# Altium schematic sheet MCU.SchDoc(MCU)
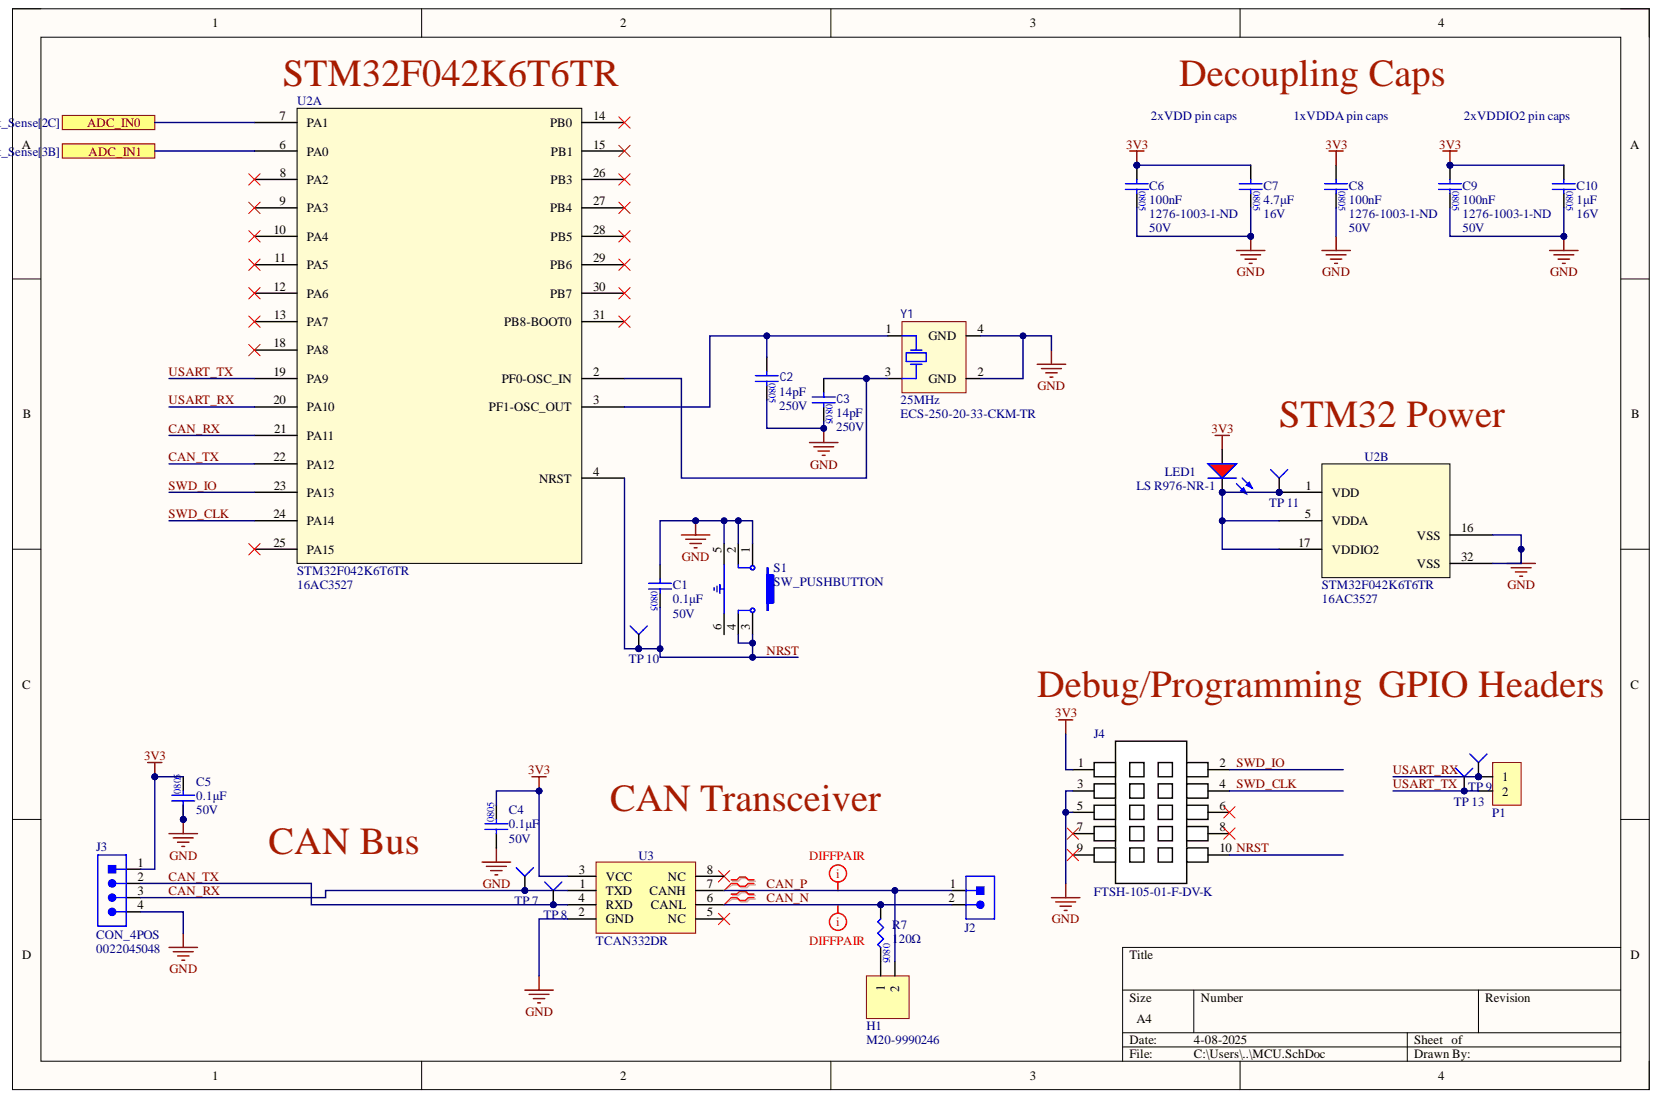
[diagram p0: MCU.SchDoc]

## Parts
C1  value 0.1μF  Package/Footprint: 0805  Voltage Rating: 50V  [CAP2012]  (hidden comment: CAP_0.1uF_50V_0805)
C2  value 14pF  Package: 0805  Voltage Rating: 250V  [CAP0805]  (hidden comment: CAP_14pF_50V_0805)
C3  value 14pF  Package: 0805  Voltage Rating: 250V  [CAP0805]  (hidden comment: CAP_14pF_50V_0805)
C4  value 0.1μF  Package/Footprint: 0805  Voltage Rating: 50V  [CAP0805]  (hidden comment: CAP_0.1uF_50V_0805)
C5  value 0.1μF  Package/Footprint: 0805  Voltage Rating: 50V  [CAP0805]  (hidden comment: CAP_0.1uF_50V_0805)
C6  value 100nF  Package/Footprint: 0805  Supplier Part Number 1: 1276-1003-1-ND  Voltage Rating: 50V  [CAP0805]  (hidden comment: CAP_100nF_50V_0805)
C7  value 4.7μF  Package: 0805  Voltage Rating: 16V  [CAP0805]  (hidden comment: CAP_4.7uF_16V_0805)
C8  value 100nF  Package/Footprint: 0805  Supplier Part Number 1: 1276-1003-1-ND  Voltage Rating: 50V  [CAP0805]  (hidden comment: CAP_100nF_50V_0805)
C9  value 100nF  Package/Footprint: 0805  Supplier Part Number 1: 1276-1003-1-ND  Voltage Rating: 50V  [CAP0805]  (hidden comment: CAP_100nF_50V_0805)
C10  value 1μF  Package: 0805  Voltage Rating: 16V  [CAP0805]  (hidden comment: CAP_1uF_16V_0805)
H1  Manufacturer Part Number 1: M20-9990246  [HDR1X2]  (hidden comment: HEADER)
J2  CON_2POS_SCREW_TERM  [1935161]  (value not drawn)
J3  CON_4POS  Manufacturer Part Number: 0022045048
J4  Manufacturer Part Number 1: FTSH-105-01-F-DV-K  [FTSH-105-01-F-DV-K-P-TR]  (hidden comment: CON_PROGRAMMING)
LED1  LED_RED  [LED_0805_RED]  (value not drawn)
P1  CONN_TERMINAL_2  [OSTYK51102030]  (value not drawn)
R7  value 120Ω  Package/Footprint: 0805  [RES0805]  (hidden comment: RES_120Ω_0805_1%)
S1  SW_PUSHBUTTON  [TACTILE_SWITCH_SMD]
TP_7  TESTPOINT_1MM_CIRCLE  [TESTPOINT_CIRCLE_1MM]  (value not drawn)  (no BOM)
TP_8  TESTPOINT_1MM_CIRCLE  [TESTPOINT_CIRCLE_1MM]  (value not drawn)  (no BOM)
TP_9  TESTPOINT_1MM_CIRCLE  [TESTPOINT_CIRCLE_1MM]  (value not drawn)  (no BOM)
TP_10  TESTPOINT_1MM_CIRCLE  [TESTPOINT_CIRCLE_1MM]  (value not drawn)  (no BOM)
TP_11  TESTPOINT_1MM_CIRCLE  [TESTPOINT_CIRCLE_1MM]  (value not drawn)  (no BOM)
TP_13  TESTPOINT_1MM_CIRCLE  [TESTPOINT_CIRCLE_1MM]  (value not drawn)  (no BOM)
U2  STM32F042K6T6TR  Supplier Part Number 1: 16AC3527  [LQFP-32]  (parts A,B)
U3  TCAN332DR  [SOIC127P599X175-8N]
Y1  Frequency: 25MHz  Manufacturer Part Number 1: ECS-250-20-33-CKM-TR  [XTAL_ECS-250-20-33-CKM-TR]  (hidden comment: CRYSTAL_25MHZ_20PF)

## Ports
ADC_IN0, ADC_IN1

## Nets
3V3: C4.2 C5.2 C6.1 C7.1 C8.1 C9.1 C10.1 J3.1 J4.1 LED1.1 U3.3(VCC)
GND: C1.1 C2.2 C3.2 C4.1 C5.1 C6.2 C7.2 C8.2 C9.2 C10.2 J3.4 J4.3 J4.5 S1.1 S1.2 S1.5 U2.16(VSS) U2.32(VSS) U3.2(GND) Y1.2(GND) Y1.4(GND)
ADC_IN0: U2.7(PA1) ; port ADC_IN0
ADC_IN1: U2.6(PA0) ; port ADC_IN1
CAN_N: J2.2 R7.1 U3.6(CANL)
CAN_P: H1.2 J2.1 U3.7(CANH)
CAN_RX: J3.3 TP_7.1 U2.21(PA11) U3.1(TXD)
CAN_TX: J3.2 TP_8.1 U2.22(PA12) U3.4(RXD)
NRST: C1.2 J4.10 S1.3 S1.4 TP_10.1 U2.4(NRST)
SWD_CLK: J4.4 U2.24(PA14)
SWD_IO: J4.2 U2.23(PA13)
USART_RX: P1.1 TP_9.1 U2.20(PA10)
USART_TX: P1.2 TP_13.1 U2.19(PA9)
NetC2_1: C2.1 U2.3(PF1-OSC_OUT) Y1.1
NetC3_1: C3.1 U2.2(PF0-OSC_IN) Y1.3
NetH1_1: H1.1 R7.2
NetLED1_2: LED1.2 TP_11.1 U2.1(VDD) U2.5(VDDA) U2.17(VDDIO2)

Not connected: J4 6 7 8 9; S1 6; U2 8(PA2) 9(PA3) 10(PA4) 11(PA5) 12(PA6) 13(PA7) 14(PB0) 15(PB1) 18(PA8) 25(PA15) 26(PB3) 27(PB4) 28(PB5) 29(PB6) 30(PB7) 31(PB8-BOOT0); U3 5(NC) 8(NC)

## Library data of the parts
C1 C4 C5 = CAP_0.1uF_50V_0805: CAP CER 0.1UF 50V X7R 0805; Manufacturer 1: Murata Electronics; Manufacturer Part Number 1: GCM21BR71H104KA37K; Component Kind: Standard; Dielectric: X7R; LCSC Part #: C49678; Mounting Type: SMD; Supplier 1: Digi-Key; Supplier Part Number 1: 490-8049-1-ND; Library Name: UWRT_Schematic_Library_2020.SchLib
C2 C3 = CAP_14pF_50V_0805: CERAMIC CAP MLCC HIGH-Q 125C; Manufacturer: Murata Electronics; Manufacturer Part Number: GQM2195C2E140JB12D; Component Kind: Standard; Mounting Type: SMD; Supplier 1: Digi-Key; Supplier Part Number 1: 490-GQM2195C2E140JB12DCT-ND; Library Name: UWRT_Schematic_Library_2020.SchLib
C6 C8 C9 = CAP_100nF_50V_0805: CAP CER 0.1UF 50V X7R 0805; Manufacturer 1: Samsung Electro-Mechanics; Manufacturer Part Number 1: CL21B104KBCNNNC; Component Kind: Standard; Supplier 1: Digi-Key; Library Name: UWRT_Schematic_Library_2020.SchLib
C7 = CAP_4.7uF_16V_0805: CAP CER 4.7UF 16V X5R 0805; Manufacturer 1: Samsung Electro-Mechanics; Manufacturer Part Number 1: CL21A475KOFNNNE; Component Kind: Standard; Dielectric: X5R; LCSC Part #: C1779; Mounting Type: SMD; Supplier 1: Digi-Key; Supplier Part Number 1: 1276-1065-1-ND; Library Name: UWRT_Schematic_Library_2020.SchLib
C10 = CAP_1uF_16V_0805: CAP CER 1UF 16V X7R 0805; Manufacturer 1: Yageo; Manufacturer Part Number 1: CC0805KKX7R7BB105; Component Kind: Standard; Dielectric: X7R; Mounting Type: SMD; Supplier 1: Digi-Key; Supplier Part Number 1: 311-1365-1-ND; Library Name: UWRT_Schematic_Library_2020.SchLib
H1 = HEADER: HEADER 2X1; Manufacturer 1: Harwin Inc.; Package/Footprint: TH; Component Kind: Standard; Mounting Type: TH; Supplier 1: Digi-Key; Supplier Part Number 1: 952-2262-ND; Library Name: UWRT_Schematic_Library_2020.SchLib
J2 = CON_2POS_SCREW_TERM: TERM BLK 2POS SIDE ENTRY 5MM; Manufacturer 1: Phoenix Contact; Package/Footprint: SMD; Component Kind: Standard; Manufacturer Part Number 1: 1935161; Mounting Type: TH; Supplier 1: Digi-Key; Supplier Part Number 1: 277-1667-ND; Library Name: UWRT_Schematic_Library_2020.SchLib
J3 = CON_4POS: CONN HEADER VERT 4POS 2.54MM; Manufacturer: Molex; Category: Headers and Wire Housings; Component Kind: Standard; ComponentLink1Description: https://datasheet.ciiva.com/6839/022045032-sd-6839882.pdf?src-supplier=Digi-Key; ComponentLink1URL: https://datasheet.ciiva.com/6839/022045032-sd-6839882.pdf?src-supplier=Digi-Key; Pricing 1: 16000=0.12858 (USD); RoHS: Yes; Supplier 1: Digi-Key; Supplier Part Number 1: 0022045048-ND; Library Name: UWRT_Schematic_Library_2020.SchLib
J4 = CON_PROGRAMMING: CONN HEADER SMD 10POS 1.27MM; Manufacturer 1: Samtec; Component Kind: Standard; Mounting Type: SMD; Pitch: 1.27mm; Supplier 1: Digi-Key; Supplier Part Number 1: SAM8796-ND; Library Name: UWRT_Schematic_Library_2020.SchLib
LED1 = LED_RED: LED RED DIFFUSED 0805 SMD; Manufacturer 1: OSRAM; Manufacturer Part Number 1: LS R976-NR-1; Forward Current: 20mA; Forward Voltage Drop: 2V; Package/Footprint: 0805; Component Kind: Standard; Mounting Type: SMD; Supplier 1: Digi-Key; Supplier Part Number 1: 475-1278-1-ND; Library Name: UWRT_Schematic_Library_2020.SchLib
P1 = CONN_TERMINAL_2: Header, 2-Pin; Manufacturer 1: On Shore Technology Inc.; Component Kind: Standard; Manufacturer Part Number 1: OSTYK51102030; Supplier 1: Digi-Key; Supplier Part Number 1: ED2953-ND; Library Name: UWRT_Schematic_Library_2020.SchLib
R7 = RES_120Ω_0805_1%: RES 120 OHM 1% 1/8W 0805; Manufacturer 1: Vishay; Manufacturer Part Number 1: CRCW0805120RFKEA; Component Kind: Standard; LCSC Part #: C17437; Mounting Type: SMD; Supplier 1: Digi-Key; Supplier Part Number 1: 541-120CCT-ND; Library Name: UWRT_Schematic_Library_2020.SchLib
S1 = SW_PUSHBUTTON: SMT 3.0 X 2.6 MM TACT SWITCH WIT; Manufacturer 1: Würth Elektronik; Manufacturer Part Number 1: 435151014824; Component Kind: Standard; Supplier 1: Digi-Key; Supplier Part Number 1: 732-435151014824CT-ND; Library Name: UWRT_Schematic_Library_2020.SchLib
TP_7-TP_11 TP_13 = TESTPOINT_1MM_CIRCLE: TESTPOINT 1MM CIRCLE; Library Name: UWRT_Schematic_Library_2020.SchLib
U2 = STM32F042K6T6TR: ARM® Cortex®-M0 STM32F0 Microcontroller IC 32-Bit Single-Core 48MHz 32KB (32K x 8) FLASH 32-LQFP (7x7); Mfr_Name: STMicroelectronics; Voltage - Supply (Vcc/Vdd): 2V ~ 3.6V; Component Kind: Standard; ComponentLink1Description: digikey; ComponentLink1URL: https://www.digikey.com/en/products/detail/stmicroelectronics/STM32F042K6T6TR/10414582; Core Processor: ARM® Cortex®-M0; Core Size: 32-Bit Single-Core; Operating Temperature: -40°C ~ 85°C (TA); Package / Case: 32-LQFP; Program Memory Size: 32KB (32K x 8); Speed: 48MHz; Supplier 1: Avnet; Library Name: UWRT_Schematic_Library_2020.SchLib
U3 = TCAN332DR: IC CAN TRANSCEIVER; Manufacturer 1: Texas Instruments; Component Kind: Standard; Manufacturer Part Number 1: TCAN332DR; Supplier 1: Digi-Key; Supplier Part Number 1: 296-43711-1-ND; Library Name: UWRT_Schematic_Library_2020.SchLib
Y1 = CRYSTAL_25MHZ_20PF: CRYSTAL 25.0000MHZ 20PF SMD; Manufacturer 1: ECS Inc.; Component Kind: Standard; Load Capacitance: 20pF; Mounting Type: SMD; Package/Footprint: SMD; Supplier 1: Digi-Key; Supplier Part Number 1: XC1817CT-ND; Library Name: UWRT_Schematic_Library_2020.SchLib
